# Altium schematic sheet Microbit built-in.SchDoc (continued)

## Library data of the parts
C38 C39 C46 = CAP CC0402JRNPO9BN240: Ceramic Capacitor, Multilayer, Ceramic, 50V, 5% +Tol, 5% -Tol, C0G, -/+30ppm/Cel TC, 0.000024uF, Surface Mount, 0402; Manufacturer: Yageo; Multilayer: Yes; Capacitor Type: Ceramic; Category: Ceramic Capacitors; Component Kind: Standard; ComponentLink1Description: https://datasheet.ciiva.com:443/pdfs/VipMasterIC/IC/YAGO/YAGOS02297/YAGOS02297-1.pdf?src-supplier=IHS+Markit; ComponentLink1URL: https://datasheet.ciiva.com:443/pdfs/VipMasterIC/IC/YAGO/YAGOS02297/YAGOS02297-1.pdf?src-supplier=IHS+Markit; ComponentLink2Description: https://datasheet.ciiva.com/144/mlccorderingcode-144079.pdf?src-supplier=Digikey; ComponentLink2URL: https://datasheet.ciiva.com/144/mlccorderingcode-144079.pdf?src-supplier=Digikey; ComponentLink3Description: https://datasheet.ciiva.com/144/mlccorderingcode-144080.pdf?src-supplier=Digikey; ComponentLink3URL: https://datasheet.ciiva.com/144/mlccorderingcode-144080.pdf?src-supplier=Digikey; ComponentLink4Description: https://datasheet.ciiva.com/144/mlccorderingcode-144081.pdf?src-supplier=Digikey; ComponentLink4URL: https://datasheet.ciiva.com/144/mlccorderingcode-144081.pdf?src-supplier=Digikey; ComponentLink5Description: https://datasheet.ciiva.com/13386/upy-gp-np0-16v-to-50v-8-13386235.pdf?src-supplier=Mouser; ComponentLink5URL: https://datasheet.ciiva.com/13386/upy-gp-np0-16v-to-50v-8-13386235.pdf?src-supplier=Mouser; ComponentLink6Description: https://datasheet.ciiva.com/7656/1132289-7656617.pdf?src-supplier=Element14; ComponentLink6URL: https://datasheet.ciiva.com/7656/1132289-7656617.pdf?src-supplier=Element14; Dielectric Material: Ceramic; JESD-609 Code: e3; Manufacturer Part Number: CC0402JRNPO9BN240; Max Operating Temperature: 125°C; Min Operating Temperature: -55°C; Mount: Surface Mount; Negative Tolerance: 5%; Number of Pins: 2; Package Shape: Rectangular; Packaging: Tape and Reel; Positive Tolerance: 5%; Pricing 1: 1=0.1, 10=0.027, 50=0.0156, 100=0.0122, 500=0.00916, 1000=0.00719, 2500=0.00654, 5000=0.00602 (USD); Rated DC Voltage (URdc): 50V; Reach SVHC Compliant: Yes; RoHS: Yes; RoHS Compliant: Yes; Size Code: 0402; Stock 1: 195258; Supplier 1: Digikey; Supplier Part Number 1: 311-1348-6-ND; Temperature Characteristics Code: C0G; Terminal Finish: Nickel; Termination: Wraparound
  C38 C39: Library Name: bBoard for UNB Rev 4.SCHLIB
  C46: Library Name: bBoard for UNB Rev 3.SCHLIB
C40 C42 = CAP CL05C101JB5NNNC: Ceramic Capacitor, Multilayer, Ceramic, 50V, 5% +Tol, 5% -Tol, C0G, -/+30ppm/Cel TC, 0.0001uF, Surface Mount, 0402; Manufacturer: Samsung; Multilayer: Yes; Capacitor Type: Ceramic; Category: Ceramic Capacitors; Component Kind: Standard; ComponentLink1Description: https://datasheet.ciiva.com:443/pdfs/VipMasterIC/IC/SEMS/SEMS-S-A0008529205/SEMS-S-A0008529205-1.pdf?src-supplier=IHS+Markit; ComponentLink1URL: https://datasheet.ciiva.com:443/pdfs/VipMasterIC/IC/SEMS/SEMS-S-A0008529205/SEMS-S-A0008529205-1.pdf?src-supplier=IHS+Markit; ComponentLink2Description: https://datasheet.ciiva.com/15195/getdatasheetpartid-357528-15195665.pdf?src-supplier=Verical; ComponentLink2URL: https://datasheet.ciiva.com/15195/getdatasheetpartid-357528-15195665.pdf?src-supplier=Verical; ComponentLink3Description: https://datasheet.ciiva.com/29198/458492-da-01-en-vs-kondensator-0402-29198529.pdf?src-supplier=Conrad; ComponentLink3URL: https://datasheet.ciiva.com/29198/458492-da-01-en-vs-kondensator-0402-29198529.pdf?src-supplier=Conrad; ComponentLink4Description: https://datasheet.ciiva.com/16379/cl05b104kq5nnnc-16379226.pdf?src-supplier=Future+Electronics; ComponentLink4URL: https://datasheet.ciiva.com/16379/cl05b104kq5nnnc-16379226.pdf?src-supplier=Future+Electronics; Dielectric Material: Ceramic; Height: 0.55mm; JESD-609 Code: e3; Length: 1mm; Manufacturer Part Number: CL05C101JB5NNNC; Max Operating Temperature: 125°C; Min Operating Temperature: -55°C; Mount: Surface Mount; Negative Tolerance: 5%; Number of Pins: 2; Package Shape: Rectangular; Package Style: SMTMeter; Packaging: Tape and Reel; Positive Tolerance: 5%; Pricing 1: 1=0.1, 10=0.017, 50=0.01, 100=0.0077, 500=0.00584, 1000=0.00458, 2500=0.00417, 5000=0.00383 (USD); Rated DC Voltage (URdc): 50V; Reach SVHC Compliant: Yes; RoHS: Yes; RoHS Compliant: Yes; Size Code: 0402; Stock 1: 4909001; Supplier 1: Digikey; Supplier Part Number 1: 1276-1025-6-ND; Temperature Characteristics Code: C0G; Terminal Finish: Nickel; Termination: Wraparound; Width: 0.5mm; Library Name: bBoard for UNB Rev 3.SCHLIB
C41 = CAP GRM155R71E103KA01D: Ceramic Capacitor, Multilayer, Ceramic, 25V, 10% +Tol, 10% -Tol, X7R, 15% TC, 0.01uF, Surface Mount, 0402; Manufacturer: Murata; Multilayer: Yes; Capacitor Type: Ceramic; Category: Ceramic Capacitors; Component Kind: Standard; ComponentLink1Description: https://psearch.en.murata.com:443/capacitor/product/GRM155R71E103KA01%23.pdf?src-supplier=IHS+Markit; ComponentLink1URL: https://psearch.en.murata.com:443/capacitor/product/GRM155R71E103KA01%23.pdf?src-supplier=IHS+Markit; ComponentLink2Description: https://datasheet.ciiva.com/28534/1699889-28534148.pdf?src-supplier=Element14; ComponentLink2URL: https://datasheet.ciiva.com/28534/1699889-28534148.pdf?src-supplier=Element14; ComponentLink3Description: https://datasheet.ciiva.com/11786/0900766b807a1cab-11786320.pdf?src-supplier=Rs; ComponentLink3URL: https://datasheet.ciiva.com/11786/0900766b807a1cab-11786320.pdf?src-supplier=Rs; ComponentLink4Description: https://datasheet.ciiva.com/129/partnumbering-e-01-129741.pdf?src-supplier=Digikey; ComponentLink4URL: https://datasheet.ciiva.com/129/partnumbering-e-01-129741.pdf?src-supplier=Digikey; ComponentLink5Description: https://datasheet.ciiva.com/129/partnumbering-e-01-129742.pdf?src-supplier=Digikey; ComponentLink5URL: https://datasheet.ciiva.com/129/partnumbering-e-01-129742.pdf?src-supplier=Digikey; ComponentLink6Description: https://datasheet.ciiva.com/129/partnumbering-e-01-129743.pdf?src-supplier=Digikey; ComponentLink6URL: https://datasheet.ciiva.com/129/partnumbering-e-01-129743.pdf?src-supplier=Digikey; ComponentLink7Description: https://datasheet.ciiva.com/3777/3813grm155r71e103ka0123-3777115.pdf?src-supplier=Arrow+Electronics; ComponentLink7URL: https://datasheet.ciiva.com/3777/3813grm155r71e103ka0123-3777115.pdf?src-supplier=Arrow+Electronics; ComponentLink8Description: https://datasheet.ciiva.com/18184/getdatasheetpartid-161489-18184689.pdf?src-supplier=Verical; ComponentLink8URL: https://datasheet.ciiva.com/18184/getdatasheetpartid-161489-18184689.pdf?src-supplier=Verical; ComponentLink9Description: https://datasheet.ciiva.com/29199/454154-da-01-en-vs-kondensator-0402-29199817.pdf?src-supplier=Conrad; ComponentLink9URL: https://datasheet.ciiva.com/29199/454154-da-01-en-vs-kondensator-0402-29199817.pdf?src-supplier=Conrad; ComponentLink10Description: https://datasheet.ciiva.com/7937/1747448-7937621.pdf?src-supplier=Element14; ComponentLink10URL: https://datasheet.ciiva.com/7937/1747448-7937621.pdf?src-supplier=Element14; ComponentLink11Description: https://datasheet.ciiva.com/16199/product-16199034.pdf?src-supplier=Future+Electronics; ComponentLink11URL: https://datasheet.ciiva.com/16199/product-16199034.pdf?src-supplier=Future+Electronics; Dielectric Material: Ceramic; Height: 0.5mm; JESD-609 Code: e3; Length: 1mm; Manufacturer Part Number: GRM155R71E103KA01D; Max Operating Temperature: 125°C; Min Operating Temperature: -55°C; Mount: Surface Mount; Negative Tolerance: 10%; Number of Pins: 2; Package Shape: Rectangular; Package Style: SMTMeter; Packaging: Tape and Reel; Positive Tolerance: 10%; Pricing 1: 1=0.1, 10=0.028, 50=0.016, 100=0.0125, 500=0.00938, 1000=0.00736, 2500=0.0067, 5000=0.00616 (USD); Rated DC Voltage (URdc): 25V; Reach SVHC Compliant: Yes; RoHS: Yes; RoHS Compliant: Yes; Size Code: 0402; Stock 1: 9724728; Supplier 1: Digikey; Supplier Part Number 1: 490-1312-1-ND; Temperature Characteristics Code: X7R; Terminal Finish: Nickel; Termination: Wraparound; Width: 0.5mm; Library Name: bBoard for UNB Rev 3.SCHLIB
C43 C45 C49-C51 = CAP GRM155R61H104KE14D: Ceramic Capacitor, Multilayer, Ceramic, 50V, 10% +Tol, 10% -Tol, X5R, 15% TC, 0.1uF, Surface Mount, 0402; Manufacturer: Murata; Multilayer: Yes; Capacitor Type: Ceramic; Category: Ceramic Capacitors; Component Kind: Standard; ComponentLink1Description: https://datasheet.ciiva.com:443/pdfs/VipMasterIC/IC/MURE/MURE-S-A0004362469/MURE-S-A0004364758-1.pdf?src-supplier=IHS+Markit; ComponentLink1URL: https://datasheet.ciiva.com:443/pdfs/VipMasterIC/IC/MURE/MURE-S-A0004362469/MURE-S-A0004364758-1.pdf?src-supplier=IHS+Markit; ComponentLink2Description: http://www.mouser.com/ds/2/281/c02e-2905.pdf?src-supplier=Mouser; ComponentLink2URL: http://www.mouser.com/ds/2/281/c02e-2905.pdf?src-supplier=Mouser; ComponentLink3Description: http://www.farnell.com/datasheets/2079150.pdf?src-supplier=Farnell; ComponentLink3URL: http://www.farnell.com/datasheets/2079150.pdf?src-supplier=Farnell; ComponentLink4Description: http://www.farnell.com/datasheets/2079150.pdf?src-supplier=Element14; ComponentLink4URL: http://www.farnell.com/datasheets/2079150.pdf?src-supplier=Element14; ComponentLink5Description: https://datasheet.octopart.com/GRM155R61H104KE14D-Murata-datasheet-66077066.pdf?src-supplier=Element14; ComponentLink5URL: https://datasheet.octopart.com/GRM155R61H104KE14D-Murata-datasheet-66077066.pdf?src-supplier=Element14; ComponentLink6Description: http://search.murata.co.jp/Ceramy/image/img/A01X/G101/ENG/GRM155R61H104KE14-01.pdf?src-supplier=Digikey; ComponentLink6URL: http://search.murata.co.jp/Ceramy/image/img/A01X/G101/ENG/GRM155R61H104KE14-01.pdf?src-supplier=Digikey; ComponentLink7Description: https://datasheet.octopart.com/GRM155R61H104KE14D-Murata-datasheet-22122223.pdf?src-supplier=Future+Electronics; ComponentLink7URL: https://datasheet.octopart.com/GRM155R61H104KE14D-Murata-datasheet-22122223.pdf?src-supplier=Future+Electronics; ComponentLink8Description: https://datasheet.octopart.com/GRM155R61H104KE14D-Murata-datasheet-149523029.pdf?src-supplier=Newark; ComponentLink8URL: https://datasheet.octopart.com/GRM155R61H104KE14D-Murata-datasheet-149523029.pdf?src-supplier=Newark; Dielectric Material: Ceramic; Height: 0.5mm; JESD-609 Code: e3; Length: 1mm; Manufacturer Part Number: GRM155R61H104KE14D; Max Operating Temperature: 85°C; Min Operating Temperature: -55°C; Mount: Surface Mount; Negative Tolerance: 10%; Number of Pins: 2; Package Shape: Rectangular; Package Style: SMTMeter; Packaging: Tape and Reel; Positive Tolerance: 10%; Pricing 1: 1=0.1, 10=0.031, 50=0.018, 100=0.0139, 500=0.01046, 1000=0.00821, 2500=0.00747, 5000=0.00687 (USD); Rated DC Voltage (URdc): 50V; Reach SVHC Compliant: Yes; RoHS: Yes; RoHS Compliant: Yes; Size Code: 0402; Stock 1: 1615754; Supplier 1: Digikey; Temperature Characteristics Code: X5R; Terminal Finish: Nickel; Termination: Wraparound; Width: 0.5mm; Library Name: bBoard for UNB Rev 3.SCHLIB
C44 C47 = CAP CC0402KRX5R5BB105: Ceramic Capacitor, Multilayer, Ceramic, 6.3V, 10% +Tol, 10% -Tol, X5R, 15% TC, 1uF, Surface Mount, 0402; Manufacturer: Yageo; Multilayer: Yes; Capacitor Type: Ceramic; Category: Ceramic Capacitors; Component Kind: Standard; ComponentLink1Description: https://datasheet.ciiva.com:443/pdfs/VipMasterIC/IC/YAGO/YAGO-S-A0006851646/YAGO-S-A0006851646-1.pdf?src-supplier=IHS+Markit; ComponentLink1URL: https://datasheet.ciiva.com:443/pdfs/VipMasterIC/IC/YAGO/YAGO-S-A0006851646/YAGO-S-A0006851646-1.pdf?src-supplier=IHS+Markit; ComponentLink2Description: https://datasheet.ciiva.com/5789/70765-5789520.pdf?src-supplier=Farnell; ComponentLink2URL: https://datasheet.ciiva.com/5789/70765-5789520.pdf?src-supplier=Farnell; ComponentLink3Description: https://datasheet.ciiva.com/176/mlccorderingcode-176609.pdf?src-supplier=Digikey; ComponentLink3URL: https://datasheet.ciiva.com/176/mlccorderingcode-176609.pdf?src-supplier=Digikey; ComponentLink4Description: https://datasheet.ciiva.com/176/mlccorderingcode-176610.pdf?src-supplier=Digikey; ComponentLink4URL: https://datasheet.ciiva.com/176/mlccorderingcode-176610.pdf?src-supplier=Digikey; ComponentLink5Description: https://datasheet.ciiva.com/176/mlccorderingcode-176611.pdf?src-supplier=Digikey; ComponentLink5URL: https://datasheet.ciiva.com/176/mlccorderingcode-176611.pdf?src-supplier=Digikey; ComponentLink6Description: https://datasheet.ciiva.com/11464/upy-gphc-x5r-4v-to-50v-12-11464863.pdf?src-supplier=Avnet; ComponentLink6URL: https://datasheet.ciiva.com/11464/upy-gphc-x5r-4v-to-50v-12-11464863.pdf?src-supplier=Avnet; ComponentLink7Description: https://datasheet.ciiva.com/8033/1525783-8033643.pdf?src-supplier=Element14; ComponentLink7URL: https://datasheet.ciiva.com/8033/1525783-8033643.pdf?src-supplier=Element14; ComponentLink8Description: https://datasheet.ciiva.com/8033/1525783-8033644.pdf?src-supplier=Element14; ComponentLink8URL: https://datasheet.ciiva.com/8033/1525783-8033644.pdf?src-supplier=Element14; ComponentLink9Description: https://datasheet.ciiva.com/8033/70765-8033646.pdf?src-supplier=Element14; ComponentLink9URL: https://datasheet.ciiva.com/8033/70765-8033646.pdf?src-supplier=Element14; ComponentLink10Description: https://datasheet.ciiva.com/28450/1359354-28450285.pdf?src-supplier=Element14; ComponentLink10URL: https://datasheet.ciiva.com/28450/1359354-28450285.pdf?src-supplier=Element14; Dielectric Material: Ceramic; Height: 0.5mm; JESD-609 Code: e3; Length: 1mm; Manufacturer Part Number: CC0402KRX5R5BB105; Max Operating Temperature: 85°C; Min Operating Temperature: -55°C; Mount: Surface Mount; Negative Tolerance: 10%; Number of Pins: 2; Package Shape: Rectangular; Package Style: SMTMeter; Packaging: Tape and Reel; Positive Tolerance: 10%; Pricing 1: 1=0.1, 10=0.054, 50=0.0308, 100=0.0239, 500=0.01796, 1000=0.01412, 2500=0.01283, 5000=0.01181 (USD); Rated DC Voltage (URdc): 6.3V; Reach SVHC Compliant: Yes; RoHS: Yes; RoHS Compliant: Yes; Size Code: 0402; Stock 1: 21426522; Supplier 1: Digikey; Supplier Part Number 1: 311-1438-1-ND; Temperature Characteristics Code: X5R; Terminal Finish: Nickel; Termination: Wraparound; Width: 0.5mm; Library Name: bBoard for UNB Rev 3.SCHLIB
C48 = CAP GRM188R60J106ME47D: Ceramic Capacitor, Multilayer, Ceramic, 6.3V, 20% +Tol, 20% -Tol, X5R, 15% TC, 10uF, Surface Mount, 0603; Manufacturer: Murata; Multilayer: Yes; Capacitor Type: Ceramic; Category: Ceramic Capacitors; Component Kind: Standard; ComponentLink1Description: https://datasheet.ciiva.com:443/pdfs/VipMasterIC/IC/MURE/MURE-S-A0008911298/MURE-S-A0008911290-1.pdf?src-supplier=IHS+Markit; ComponentLink1URL: https://datasheet.ciiva.com:443/pdfs/VipMasterIC/IC/MURE/MURE-S-A0008911298/MURE-S-A0008911290-1.pdf?src-supplier=IHS+Markit; ComponentLink2Description: https://datasheet.ciiva.com/22776/1747457-22776418.pdf?src-supplier=Farnell; ComponentLink2URL: https://datasheet.ciiva.com/22776/1747457-22776418.pdf?src-supplier=Farnell; ComponentLink3Description: https://datasheet.ciiva.com/28584/415295-28584232.pdf?src-supplier=Element14; ComponentLink3URL: https://datasheet.ciiva.com/28584/415295-28584232.pdf?src-supplier=Element14; ComponentLink4Description: https://datasheet.ciiva.com/3777/10175grm188r60j106me4723-3777268.pdf?src-supplier=Arrow+Electronics; ComponentLink4URL: https://datasheet.ciiva.com/3777/10175grm188r60j106me4723-3777268.pdf?src-supplier=Arrow+Electronics; ComponentLink5Description: https://datasheet.ciiva.com/29199/454401-da-01-en-vs-kondensator-0603-29199677.pdf?src-supplier=Conrad; ComponentLink5URL: https://datasheet.ciiva.com/29199/454401-da-01-en-vs-kondensator-0603-29199677.pdf?src-supplier=Conrad; ComponentLink6Description: https://datasheet.ciiva.com/29202/450781-da-01-de-vs-chip-kond-0603-10-0-uf-20pr-6-3v-x5r-29202806.pdf?src-supplier=Conrad; ComponentLink6URL: https://datasheet.ciiva.com/29202/450781-da-01-de-vs-chip-kond-0603-10-0-uf-20pr-6-3v-x5r-29202806.pdf?src-supplier=Conrad; ComponentLink7Description: https://datasheet.ciiva.com/138/partnumbering-e-01-138658.pdf?src-supplier=Digikey; ComponentLink7URL: https://datasheet.ciiva.com/138/partnumbering-e-01-138658.pdf?src-supplier=Digikey; ComponentLink8Description: https://datasheet.ciiva.com/138/partnumbering-e-01-138659.pdf?src-supplier=Digikey; ComponentLink8URL: https://datasheet.ciiva.com/138/partnumbering-e-01-138659.pdf?src-supplier=Digikey; ComponentLink9Description: https://datasheet.ciiva.com/138/partnumbering-e-01-138660.pdf?src-supplier=Digikey; ComponentLink9URL: https://datasheet.ciiva.com/138/partnumbering-e-01-138660.pdf?src-supplier=Digikey; ComponentLink10Description: https://datasheet.ciiva.com/14116/grmseries-highdielectrictype-eng-14116428.pdf?src-supplier=Distrelec; ComponentLink10URL: https://datasheet.ciiva.com/14116/grmseries-highdielectrictype-eng-14116428.pdf?src-supplier=Distrelec; ComponentLink11Description: https://datasheet.ciiva.com/16373/l0005e-16373580.pdf?src-supplier=Future+Electronics; ComponentLink11URL: https://datasheet.ciiva.com/16373/l0005e-16373580.pdf?src-supplier=Future+Electronics; Dielectric Material: Ceramic; Height: 0.8mm; JESD-609 Code: e3; Length: 1.6mm; Manufacturer Part Number: GRM188R60J106ME47D; Max Operating Temperature: 85°C; Min Operating Temperature: -55°C; Mount: Surface Mount; Negative Tolerance: 20%; Number of Pins: 2; Package Shape: Rectangular; Package Style: SMTMeter; Packaging: Tape and Reel; Positive Tolerance: 20%; Pricing 1: 1=0.17, 10=0.116, 50=0.0692, 100=0.0544, 500=0.04022, 1000=0.03329 (USD); Rated DC Voltage (URdc): 6.3V; Reach SVHC Compliant: Yes; RoHS: Yes; RoHS Compliant: Yes; Size Code: 0603; Stock 1: 1071844; Supplier 1: Digikey; Supplier Part Number 1: 490-3896-1-ND; Temperature Characteristics Code: X5R; Terminal Finish: Nickel; Termination: Wraparound; Width: 0.8mm; Library Name: bBoard for UNB Rev 3.SCHLIB
C53 = CAP GJM1555C1H1R2JB01D: Ceramic Capacitor, Multilayer, Ceramic, 50V, 5% +Tol, 5% -Tol, C0G, 30ppm/Cel TC, 0.0000012uF, Surface Mount, 0402; Manufacturer: Murata; Multilayer: Yes; Capacitor Type: Ceramic; Category: Ceramic Capacitors; Component Kind: Standard; ComponentLink1Description: https://datasheet.ciiva.com:443/pdfs/VipMasterIC/IC/MURE/MURE-S-A0004378008/MURE-S-A0004383049-1.pdf?src-supplier=IHS+Markit; ComponentLink1URL: https://datasheet.ciiva.com:443/pdfs/VipMasterIC/IC/MURE/MURE-S-A0004378008/MURE-S-A0004383049-1.pdf?src-supplier=IHS+Markit; ComponentLink2Description: http://datasheet.octopart.com/GJM1555C1H1R2JB01D-Murata-datasheet-8819587.pdf?src-supplier=Farnell; ComponentLink2URL: http://datasheet.octopart.com/GJM1555C1H1R2JB01D-Murata-datasheet-8819587.pdf?src-supplier=Farnell; ComponentLink3Description: http://datasheet.octopart.com/GJM1555C1H1R2JB01D-Murata-datasheet-8819587.pdf?src-supplier=Digikey; ComponentLink3URL: http://datasheet.octopart.com/GJM1555C1H1R2JB01D-Murata-datasheet-8819587.pdf?src-supplier=Digikey; ComponentLink4Description: http://datasheet.octopart.com/GJM1555C1H1R2JB01D-Murata-datasheet-8819587.pdf?src-supplier=Avnet; ComponentLink4URL: http://datasheet.octopart.com/GJM1555C1H1R2JB01D-Murata-datasheet-8819587.pdf?src-supplier=Avnet; ComponentLink5Description: http://datasheet.octopart.com/GJM1555C1H1R2JB01D-Murata-datasheet-8819587.pdf?src-supplier=Mouser; ComponentLink5URL: http://datasheet.octopart.com/GJM1555C1H1R2JB01D-Murata-datasheet-8819587.pdf?src-supplier=Mouser; Dielectric Material: Ceramic; Manufacturer Part Number: GJM1555C1H1R2JB01D; Max Operating Temperature: 125°C; Min Operating Temperature: -55°C; Mount: Surface Mount; Negative Tolerance: 5%; Number of Pins: 2; Package Shape: Rectangular; Packaging: Tape and Reel; Positive Tolerance: 5%; Pricing 1: 1=0.12, 10=0.081, 50=0.0484, 100=0.0381, 500=0.02816, 1000=0.02331, 2500=0.02169, 5000=0.01984 (USD); Rated DC Voltage (URdc): 50V; Reach SVHC Compliant: Yes; RoHS: Yes; RoHS Compliant: Yes; Size Code: 0402; Stock 1: 11165; Supplier 1: Digikey; Supplier Part Number 1: 490-GJM1555C1H1R2JB01DCT-ND; Temperature Characteristics Code: C0G; Termination: Wraparound; Library Name: bBoard for UNB Rev 4.SCHLIB
C54 = CAP GCM1555C1H1R0JA16D: Ceramic Capacitor, Multilayer, Ceramic, 50V, 5% +Tol, 5% -Tol, C0G, 30ppm/Cel TC, 0.000001uF, Surface Mount, 0402; Manufacturer: Murata; Multilayer: Yes; Reference Standard: AEC-Q200; Capacitor Type: Ceramic; Category: Ceramic Capacitors; Component Kind: Standard; ComponentLink1Description: https://psearch.en.murata.com:443/capacitor/product/GCM1555C1H1R0JA16%23.pdf?src-supplier=IHS+Markit; ComponentLink1URL: https://psearch.en.murata.com:443/capacitor/product/GCM1555C1H1R0JA16%23.pdf?src-supplier=IHS+Markit; ComponentLink2Description: https://datasheet.octopart.com/GCM1555C1H1R0JA16D-Murata-datasheet-12511495.pdf?src-supplier=TME; ComponentLink2URL: https://datasheet.octopart.com/GCM1555C1H1R0JA16D-Murata-datasheet-12511495.pdf?src-supplier=TME; Dielectric Material: Ceramic; Manufacturer Part Number: GCM1555C1H1R0JA16D; Max Operating Temperature: 125°C; Min Operating Temperature: -55°C; Mount: Surface Mount; Negative Tolerance: 5%; Number of Pins: 2; Package Shape: Rectangular; Packaging: Tape and Reel; Positive Tolerance: 5%; Pricing 1: 1=0.1, 10=0.046, 50=0.0266, 100=0.0206, 500=0.0155, 1000=0.01218, 2500=0.01107, 5000=0.01019 (USD); Rated DC Voltage (URdc): 50V; Reach SVHC Compliant: Yes; RoHS: Yes; RoHS Compliant: Yes; Size Code: 0402; Stock 1: 43826; Supplier 1: Digikey; Supplier Part Number 1: 490-GCM1555C1H1R0JA16DCT-ND; Temperature Characteristics Code: C0G; Termination: Wraparound; Library Name: bBoard for UNB Rev 4.SCHLIB
D4 = LED_0603_RED: LED, Red, 630 nm, 1.9 V, 30 mA, 60 mcd; Manufacturer: Wurth Electronics; Manufacturer Part Number: 150060SS75000; Dominant Wavelength: 630nm; Forward Current: 30mA; Forward Voltage: 1.9V; Lens Style: Clear,Rectangular; Luminous Intensity: 60mcd; Test Current: 20mA; Viewing Angle: 140°; Case Code (Imperial): 0603; Case Code (Metric): 1608; Case/Package: 0603; Category: LEDs; Color: Red; Component Kind: Standard; ComponentLink1Description: https://datasheet.ciiva.com/23843/150060ss75000-23843248.pdf?src-supplier=Newark; ComponentLink1URL: https://datasheet.ciiva.com/23843/150060ss75000-23843248.pdf?src-supplier=Newark; ComponentLink2Description: https://datasheet.ciiva.com/29279/759429-da-01-en-chipled-0603-w-kl-s-rot-150060ss75000-29279208.pdf?src-supplier=Conrad; ComponentLink2URL: https://datasheet.ciiva.com/29279/759429-da-01-en-chipled-0603-w-kl-s-rot-150060ss75000-29279208.pdf?src-supplier=Conrad; ComponentLink3Description: https://datasheet.ciiva.com/48430/150060ss75000-eng-tds-48430042.pdf?src-supplier=Distrelec; ComponentLink3URL: https://datasheet.ciiva.com/48430/150060ss75000-eng-tds-48430042.pdf?src-supplier=Distrelec; Height: 0.7mm; Length: 1.6mm; Max Operating Temperature: 85°C; Min Operating Temperature: -40°C; Mount: Surface Mount; Package Quantity: 4000; Packaging: Tape and Reel; REACH SVHC: Unknown; RoHS: Yes; Stock 1: 15937; Supplier 1: Digi-Key; Supplier Part Number 1: 732-4979-1-ND; Wavelength: 630nm; Width: 0.8mm; Library Name: bBoard for UNB Rev 4.SCHLIB
D5 = DIODE BAS16LD,315: Rectifier Diode, 1 Phase, 1 Element, 0.215A, 100V V(RRM), Silicon; Manufacturer: Nexperia; Max Output Current: 215mA; Max Power Dissipation: 250mW; Number of Elements: 1; Package Body Material: Plastic; Reference Standard: AEC-Q101; Reverse Recovery Time-Max: 0.004us; Time @ Peak Reflow Temperature-Max (s): 30s; Category: Rectifier Diodes; Component Kind: Standard; ComponentLink1Description: https://datasheet.ciiva.com:443/pdfs/VipMasterIC/IC/NEXP/NEXP-S-A0002883683/NEXP-S-A0002883683-1.pdf?src-supplier=IHS+Markit; ComponentLink1URL: https://datasheet.ciiva.com:443/pdfs/VipMasterIC/IC/NEXP/NEXP-S-A0002883683/NEXP-S-A0002883683-1.pdf?src-supplier=IHS+Markit; Diode Element Material: SILICON; Diode Type: RECTIFIER; JESD-609 Code: e3; Manufacturer Part Number: BAS16LD,315; Max Operating Temperature: 150°C; Min Operating Temperature: -55°C; Moisture Sensitivity Level: 1; Number of Pins: 2; Package Shape: Rectangular; Package Style: SMALL OUTLINEMeter; Peak Reflow Temperature (Cel): 260°C; Pricing 1: 1=0.26, 10=0.176, 100=0.0859, 500=0.07168, 1000=0.04981, 2000=0.04317, 5000=0.04004 (USD); Reach SVHC Compliant: Yes; Rep Pk Reverse Voltage-Max: 100V; RoHS: Yes; RoHS Compliant: Yes; Stock 1: 205336; Supplier 1: Digikey; Supplier Part Number 1: 1727-4421-1-ND; Surface Mount: Yes; Terminal Finish: Tin; Terminal Form: No Lead; Terminal Position: DUAL; Library Name: bBoard for UNB Rev 4.SCHLIB
E1 = Antenna: RF ANT 2.4GHZ CHIP SOLDER SMD; Manufacturer: Linx; Bandwidth: 180MHz; Frequency: 2.45GHz; Gain: 0.5dB; Impedance: 50R; Max Power Dissipation: 3W; Number of Bands: 1; Case/Package: SMD/SMT; Category: RF Antennas; Component Kind: Standard; ComponentLink1Description: https://datasheet.ciiva.com/16287/ant-xxx-chp-x-16287420.pdf?src-supplier=Future+Electronics; ComponentLink1URL: https://datasheet.ciiva.com/16287/ant-xxx-chp-x-16287420.pdf?src-supplier=Future+Electronics; ComponentLink2Description: https://datasheet.ciiva.com/2792/ant-xxx-chp-x-2792221.pdf?src-supplier=Digi-Key; ComponentLink2URL: https://datasheet.ciiva.com/2792/ant-xxx-chp-x-2792221.pdf?src-supplier=Digi-Key; ComponentLink3Description: https://datasheet.ciiva.com/2792/ant-xxx-chp-x-2792222.pdf?src-supplier=Digi-Key; ComponentLink3URL: https://datasheet.ciiva.com/2792/ant-xxx-chp-x-2792222.pdf?src-supplier=Digi-Key; ComponentLink4Description: https://datasheet.ciiva.com/2792/ant-xxx-chp-x-2792223.pdf?src-supplier=Digi-Key; ComponentLink4URL: https://datasheet.ciiva.com/2792/ant-xxx-chp-x-2792223.pdf?src-supplier=Digi-Key; ComponentLink5Description: https://datasheet.ciiva.com/4432/ant-xxx-chp-x-data-guide-11938-4432415.pdf?src-supplier=Mouser; ComponentLink5URL: https://datasheet.ciiva.com/4432/ant-xxx-chp-x-data-guide-11938-4432415.pdf?src-supplier=Mouser; Height: 0.002inch; Lead Free: Lead Free; Length: 0.26inch; Manufacturer Part Number: ANT-2.45-CHP-T; Max Operating Temperature: 85°C; Min Operating Temperature: -40°C; Mount: Surface Mount; Package Quantity: 1; Packaging: Tape and Reel; RoHS: Yes; Stock 1: 10182; Supplier 1: Digi-Key; Supplier Part Number 1: ANT-2.45-CHPCT-ND; Termination: Solder; Width: 0.09inch; Library Name: bBoard for UNB Rev 4.SCHLIB
L5 = LQM18FN100M00D: General Purpose Inductor, 10uH, 20%, 1 Element, Ferrite-Core, SMD, 0603; Manufacturer: Murata; Case/Size Code: 0603; DC Resistance (DCR): 1.17R; Inductor Application: RF INDUCTOR; Number of Functions: 1; Package Height: 0.8mm; Package Length: 1.6mm; Rated Current-Max: 50mA; Self Resonant Frequency: 30MHz; Shape/Size Description: RECTANGULAR PACKAGE; Shielding: Shielded; Test Frequency: 1MHz; Category: Fixed Inductors; Component Kind: Standard; ComponentLink1Description: https://psearch.en.murata.com:443/inductor/product/LQM18FN100M00_.pdf?src-supplier=IHS+Markit; ComponentLink1URL: https://psearch.en.murata.com:443/inductor/product/LQM18FN100M00_.pdf?src-supplier=IHS+Markit; ComponentLink2Description: https://datasheet.ciiva.com/3865/286lqm18fn100m0023-3865365.pdf?src-supplier=Arrow+Electronics; ComponentLink2URL: https://datasheet.ciiva.com/3865/286lqm18fn100m0023-3865365.pdf?src-supplier=Arrow+Electronics; ComponentLink3Description: https://datasheet.ciiva.com/11799/0900766b80f15512-11799471.pdf?src-supplier=Rs; ComponentLink3URL: https://datasheet.ciiva.com/11799/0900766b80f15512-11799471.pdf?src-supplier=Rs; ComponentLink4Description: https://datasheet.ciiva.com/1039/l0075s0097lqm18f-1039417.pdf?src-supplier=Digikey; ComponentLink4URL: https://datasheet.ciiva.com/1039/l0075s0097lqm18f-1039417.pdf?src-supplier=Digikey; ComponentLink5Description: https://datasheet.ciiva.com/1039/l0075s0097lqm18f-1039418.pdf?src-supplier=Digikey; ComponentLink5URL: https://datasheet.ciiva.com/1039/l0075s0097lqm18f-1039418.pdf?src-supplier=Digikey; ComponentLink6Description: https://datasheet.ciiva.com/1039/l0075s0097lqm18f-1039419.pdf?src-supplier=Digikey; ComponentLink6URL: https://datasheet.ciiva.com/1039/l0075s0097lqm18f-1039419.pdf?src-supplier=Digikey; ComponentLink7Description: https://datasheet.ciiva.com/8510/l0075s0097lqm18f-8510087.pdf?src-supplier=Avnet; ComponentLink7URL: https://datasheet.ciiva.com/8510/l0075s0097lqm18f-8510087.pdf?src-supplier=Avnet; ComponentLink8Description: https://datasheet.ciiva.com/8510/l0075s0097lqm18f-8510328.pdf?src-supplier=Avnet; ComponentLink8URL: https://datasheet.ciiva.com/8510/l0075s0097lqm18f-8510328.pdf?src-supplier=Avnet; Construction: Multilayer Chip; Core Material: Ferrite; Inductance-Nom (L): 10uH; Inductor Type: GENERAL PURPOSE INDUCTOR; JESD-609 Code: e3; Manufacturer Part Number: LQM18FN100M00D; Max Operating Temperature: 125°C; Min Operating Temperature: -55°C; Number of Pins: 2; Package Style: SMTMeter; Package Width: 0.8mm; Packaging: Tape and Reel; Pricing 1: 1=0.18, 10=0.149, 25=0.1372, 50=0.1212, 100=0.106, 250=0.10096, 500=0.09488, 1000=0.07268 (USD); Reach SVHC Compliant: Yes; RoHS: Yes; RoHS Compliant: Yes; Stock 1: 351983; Supplier 1: Digikey; Supplier Part Number 1: 490-4025-1-ND; Surface Mount: Yes; Terminal Finish: Tin; Terminal Placement: Dual Ended; Termination: Wraparound; Tolerance: 20%; Library Name: bBoard for UNB Rev 3.SCHLIB
L6 = MLT-8530: Speaker magnetic 9mm SMT; Manufacturer: Jiangsu Huaneng Elec; Component Kind: Standard; Manufacturer Part Number: MLT-8530; Supplier: LCSC; Library Name: bBoard for UNB Rev 4.SCHLIB
L7 = L AISC-0402-2N2J-T: General Purpose Inductor, 0.0022uH, 5%, 1 Element, Ceramic-Core, SMD, 0503; Manufacturer: Abracon; Case/Size Code: 0402; DC Resistance (DCR): 70mR; Inductor Application: RF INDUCTOR; Number of Functions: 1; Quality Factor-Min (at L-nom): 18; Rated Current-Max: 960mA; Self Resonant Frequency: 10.8GHz; Shape/Size Description: RECTANGULAR PACKAGE; Shielding: Unshielded; Test Frequency: 250MHz; Category: Fixed Inductors; Component Kind: Standard; ComponentLink1Description: https://datasheet.ciiva.com:443/pdfs/VipMasterIC/IC/ABRC/ABRC-S-A0009700385/ABRC-S-A0009751294-1.pdf?src-supplier=IHS+Markit; ComponentLink1URL: https://datasheet.ciiva.com:443/pdfs/VipMasterIC/IC/ABRC/ABRC-S-A0009700385/ABRC-S-A0009751294-1.pdf?src-supplier=IHS+Markit; ComponentLink2Description: https://datasheet.ciiva.com/1054/aisc-0402-1054033.pdf?src-supplier=Digikey; ComponentLink2URL: https://datasheet.ciiva.com/1054/aisc-0402-1054033.pdf?src-supplier=Digikey; ComponentLink3Description: https://datasheet.ciiva.com/1054/aisc-0402-1054034.pdf?src-supplier=Digikey; ComponentLink3URL: https://datasheet.ciiva.com/1054/aisc-0402-1054034.pdf?src-supplier=Digikey; ComponentLink4Description: https://datasheet.ciiva.com/1054/aisc-0402-1054035.pdf?src-supplier=Digikey; ComponentLink4URL: https://datasheet.ciiva.com/1054/aisc-0402-1054035.pdf?src-supplier=Digikey; ComponentLink5Description: https://datasheet.ciiva.com/11140/aisc-0402-11140263.pdf?src-supplier=Avnet; ComponentLink5URL: https://datasheet.ciiva.com/11140/aisc-0402-11140263.pdf?src-supplier=Avnet; Core Material: Ceramic; Inductor Type: GENERAL PURPOSE INDUCTOR; JESD-609 Code: e4; Manufacturer Part Number: AISC-0402-2N2J-T; Max Operating Temperature: 125°C; Min Operating Temperature: -40°C; Number of Pins: 2; Pricing 1: 1=0.27, 10=0.217, 25=0.2, 50=0.1834, 100=0.1617, 250=0.15664, 500=0.13998, 1000=0.11332, 2500=0.10666 (USD); Reach SVHC Compliant: Yes; RoHS: Yes; RoHS Compliant: Yes; Stock 1: 17497; Supplier 1: Digikey; Supplier Part Number 1: 535-10425-1-ND; Surface Mount: Yes; Terminal Finish: Nickel; Terminal Placement: Dual Ended; Termination: Wraparound; Tolerance: 5%; Library Name: bBoard for UNB Rev 4.SCHLIB
L8 = L CW100505-4N7J: General Purpose Inductor, 0.0047uH, 5%, 1 Element, Ceramic-Core, SMD, 0402; Manufacturer: Bourns; Case/Size Code: 0402; DC Resistance (DCR): 130mR; Inductor Application: RF INDUCTOR; Number of Functions: 1; Package Height: 0.5mm; Package Length: 1mm; Package Width: 0.55mm; Quality Factor-Min (at L-nom): 15; Rated Current-Max: 640mA; Self Resonant Frequency: 4.775GHz; Shape/Size Description: RECTANGULAR PACKAGE; Shielding: Unshielded; Test Frequency: 250MHz; Category: Fixed Inductors; Component Kind: Standard; ComponentLink1Description: https://datasheet.ciiva.com:443/pdfs/VipMasterIC/IC/BOUR/BOUR-S-A0006522652/BOUR-S-A0006522652-1.pdf?src-supplier=IHS+Markit; ComponentLink1URL: https://datasheet.ciiva.com:443/pdfs/VipMasterIC/IC/BOUR/BOUR-S-A0006522652/BOUR-S-A0006522652-1.pdf?src-supplier=IHS+Markit; ComponentLink2Description: https://datasheet.ciiva.com/6688/cw100505-6688922.pdf?src-supplier=Digikey; ComponentLink2URL: https://datasheet.ciiva.com/6688/cw100505-6688922.pdf?src-supplier=Digikey; ComponentLink3Description: https://datasheet.ciiva.com/6688/cw100505-6688926.pdf?src-supplier=Digikey; ComponentLink3URL: https://datasheet.ciiva.com/6688/cw100505-6688926.pdf?src-supplier=Digikey; ComponentLink4Description: https://datasheet.ciiva.com/3079/cw100505-3079092.pdf?src-supplier=Avnet; ComponentLink4URL: https://datasheet.ciiva.com/3079/cw100505-3079092.pdf?src-supplier=Avnet; ComponentLink5Description: https://datasheet.ciiva.com/3079/cw100505-3079781.pdf?src-supplier=Avnet; ComponentLink5URL: https://datasheet.ciiva.com/3079/cw100505-3079781.pdf?src-supplier=Avnet; ComponentLink6Description: https://datasheet.ciiva.com/17113/70250284-17113126.pdf?src-supplier=Allied+Electronics; ComponentLink6URL: https://datasheet.ciiva.com/17113/70250284-17113126.pdf?src-supplier=Allied+Electronics; ComponentLink7Description: https://datasheet.ciiva.com/7848/1758342-7848026.pdf?src-supplier=Element14; ComponentLink7URL: https://datasheet.ciiva.com/7848/1758342-7848026.pdf?src-supplier=Element14; Construction: Chip; Core Material: Ceramic; Inductor Type: GENERAL PURPOSE INDUCTOR; JESD-609 Code: e4; Manufacturer Part Number: CW100505-4N7J; Max Operating Temperature: 125°C; Min Operating Temperature: -55°C; Number of Pins: 2; Package Style: SMTMeter; Packaging: Tape and Reel; Pricing 1: 1=0.22, 10=0.179, 25=0.1648, 50=0.1512, 100=0.1333, 250=0.12916, 500=0.1154, 1000=0.09675 (USD); Reach SVHC Compliant: Yes; RoHS: Yes; RoHS Compliant: Yes; Stock 1: 280750; Supplier 1: Digikey; Supplier Part Number 1: CW100505-4N7JCT-ND; Surface Mount: Yes; Terminal Finish: Gold; Terminal Placement: Dual Ended; Termination: One Surface; Tolerance: 5%; Library Name: bBoard for UNB Rev 4.SCHLIB
P1 = Header 2X8 - 0901512116: Board Connector; Manufacturer: Molex; Component Kind: Standard; ComponentLink1Description: https://datasheet.ciiva.com:443/pdfs/VipMasterIC/IC/MOLE/MOLE-S-A0006864014/MOLE-S-A0006765232-1.pdf?src-supplier=IHS+Markit; ComponentLink1URL: https://datasheet.ciiva.com:443/pdfs/VipMasterIC/IC/MOLE/MOLE-S-A0006864014/MOLE-S-A0006765232-1.pdf?src-supplier=IHS+Markit; ComponentLink2Description: http://components.arrow.com/part/dataview/2928146S8734086N3303?src-supplier=Arrow+Electronics; ComponentLink2URL: http://components.arrow.com/part/dataview/2928146S8734086N3303?src-supplier=Arrow+Electronics; ComponentLink3Description: https://datasheet.octopart.com/90151-2116-Molex-datasheet-101584149.pdf?src-supplier=Farnell; ComponentLink3URL: https://datasheet.octopart.com/90151-2116-Molex-datasheet-101584149.pdf?src-supplier=Farnell; ComponentLink4Description: https://datasheet.octopart.com/90151-2116-Molex-datasheet-10854273.pdf?src-supplier=Newark; ComponentLink4URL: https://datasheet.octopart.com/90151-2116-Molex-datasheet-10854273.pdf?src-supplier=Newark; ComponentLink5Description: https://datasheet.octopart.com/90151-2116-Molex-datasheet-44403541.pdf?src-supplier=Electro+Sonic; ComponentLink5URL: https://datasheet.octopart.com/90151-2116-Molex-datasheet-44403541.pdf?src-supplier=Electro+Sonic; ComponentLink6Description: https://datasheet.octopart.com/90151-2116-Molex-datasheet-81455539.pdf?src-supplier=TME; ComponentLink6URL: https://datasheet.octopart.com/90151-2116-Molex-datasheet-81455539.pdf?src-supplier=TME; ComponentLink7Description: https://datasheet.octopart.com/90151-2116-Molex-datasheet-154761127.pdf?src-supplier=Master+Electronics; ComponentLink7URL: https://datasheet.octopart.com/90151-2116-Molex-datasheet-154761127.pdf?src-supplier=Master+Electronics; Manufacturer Part Number: 0901512116; Pricing 1: 1=3.89, 10=2.75, 25=2.67, 50=2.59, 100=2.52, 250=2.43 (USD); RoHS: Yes; Stock 1: 3895; Supplier 1: Newark; Supplier Part Number 1: 94M3066; Library Name: bBoard for UNB Rev 4.SCHLIB
Q2 Q3 = DTC143EET1G: Small Signal Bipolar Transistor, 0.1A I(C), 50V V(BR)CEO, 1-Element, NPN, Silicon; Manufacturer: ON Semiconductor; DC Current Gain-Min (hFE): 15; Max Collector Current: 100mA; Number of Elements: 1; Package Body Material: Plastic; Power Dissipation-Max (Abs): 300mW; Qualification Status: Not Qualified; Time @ Peak Reflow Temperature-Max (s): 40s; Transistor Application: SWITCHING; Category: BJTs; Collector-emitter Voltage-Max: 50V; Component Kind: Standard; ComponentLink1Description: https://datasheet.ciiva.com:443/pdfs/VipMasterIC/IC/ONSM/ONSM-S-A0002809175/ONSM-S-A0002809175-1.pdf?src-supplier=IHS+Markit; ComponentLink1URL: https://datasheet.ciiva.com:443/pdfs/VipMasterIC/IC/ONSM/ONSM-S-A0002809175/ONSM-S-A0002809175-1.pdf?src-supplier=IHS+Markit; ComponentLink2Description: https://datasheet.ciiva.com/15139/getdatasheetpartid-38523-15139560.pdf?src-supplier=Verical; ComponentLink2URL: https://datasheet.ciiva.com/15139/getdatasheetpartid-38523-15139560.pdf?src-supplier=Verical; ComponentLink3Description: https://datasheet.ciiva.com/990/dtc143e-d-990648.pdf?src-supplier=Digikey; ComponentLink3URL: https://datasheet.ciiva.com/990/dtc143e-d-990648.pdf?src-supplier=Digikey; ComponentLink4Description: https://datasheet.ciiva.com/8120/dtc124e-d-8120717.pdf?src-supplier=Avnet; ComponentLink4URL: https://datasheet.ciiva.com/8120/dtc124e-d-8120717.pdf?src-supplier=Avnet; JESD-30 Code: R-PDSO-G3; JESD-609 Code: e3; Manufacturer Part Number: DTC143EET1G; Max Operating Temperature: 150°C; Moisture Sensitivity Level: 1; Number of Pins: 3; Package Shape: Rectangular; Package Style: SMALL OUTLINEMeter; Peak Reflow Temperature (Cel): 260°C; Polarity/Channel Type: NPN; Pricing 1: 1=0.2, 10=0.14, 100=0.0684, 500=0.05708, 1000=0.03966 (USD); Reach SVHC Compliant: Yes; RoHS: Yes; RoHS Compliant: Yes; Stock 1: 287169; Supplier 1: Digikey; Supplier Part Number 1: DTC143EET1GOSDKR-ND; Surface Mount: Yes; Terminal Finish: Tin; Terminal Form: Gull Wing; Terminal Position: DUAL; Transistor Element Material: SILICON; Library Name: bBoard for UNB Rev 4.SCHLIB
Q4 = BSS816NW: N-Channel OptiMOS 2 Small-Signal-Transistor, 20 V VDS, 1.4 A ID, -55 to 150 degC, PG-SOT-323, Reel, Green; Manufacturer: Infineon; Green: TRUE; ID (max): 1.4Â A; IDpuls (max): 5.6Â A; Ptot (max): 0.5Â W; QG: 0.6Â nC; RDS (on) (max): 160 mOhm; RDS (on) (max) (@1.8V): 240 mOhm; RthJA (max): 250 K/W; VDS (max): 20 V; VGS(th) (max): 0.75Â V; VGS(th) (min): 0.3Â V; Component Kind: Standard; ComponentLink1Description: Manufacturer URL; ComponentLink1URL: http://www.infineon.com/; ComponentLink2Description: Datasheet; ComponentLink2URL: http://www.infineon.com/dgdl/BSS816NW_Rev2.3_.pdf?folderId=db3a3043156fd573011622e10b5c1f67&fileId=db3a304335113a6301351e704a2e1332; ComponentLink3Description: Package Specification; ComponentLink3URL: http://www.infineon.com/dgdl/SOT323-Package_Overview.pdf?folderId=db3a30431936bc4b0119533e1dcb3c92&fileId=db3a30431936bc4b011953495f283cb1; DatasheetVersion: Rev. 2.3; Halogen-free: TRUE; Mounting Technology: Surface Mount; PackageDescription: 3-Pin Small Outline Transistor Package, 2 x 1.25 mm Body, 0.65 mm Pitch; PackageReference: PG-SOT-323; PackageVersion: Apr-08; Packing: Reel; PartNumber: BSS816NW; RDS (on) (max) (@2.5V): 160 mOhm; Library Name: bBoard for UNB Rev 3.SCHLIB
R18-R20 R60 = RES MCWR04X1005FTL: Res General Purpose Thick Film 0402 10M Ohm 1% 1/16W ±100ppm/°C Ceramic Paper T/R; Manufacturer: Multicomp; Component Kind: Standard; ComponentLink1Description: https://datasheet.ciiva.com/46398/1849611-46398249.pdf?src-supplier=Element14; ComponentLink1URL: https://datasheet.ciiva.com/46398/1849611-46398249.pdf?src-supplier=Element14; Manufacturer Part Number: MCWR04X1005FTL; Pricing 1: 10=0.034, 100=0.028, 1000=0.02 (CAD); RoHS: Yes; Stock 1: 27572; Supplier 1: Newark; Supplier Part Number 1: 94X1108; Library Name: bBoard for UNB Rev 4.SCHLIB
R21 R22 R29 = RES MC00625W040211K: Resistor, Thick Film, 1K, 1%, 0.0625W, 0402; Manufacturer: Multicomp; Component Kind: Standard; Part Number: MC00625W040211K; Library Name: bBoard for UNB Rev 3.SCHLIB
R23 R28 = RES MC00625W0402110K: Fixed Resistor, Metal Glaze/thick Film, 0.0625W, 10000ohm, 50V, 1% +/-Tol, 100ppm/Cel, Surface Mount, 0402; Manufacturer: Multicomp; Rated Power Dissipation (P): 63mW; Rated Temperature: 70°C; Temperature Coefficient: 100ppm/°C; Category: Chip SMD Resistors; Component Kind: Standard; ComponentLink1Description: https://datasheet.ciiva.com:443/pdfs/VipMasterIC/IC/SCMP/SCMPS00098/SCMPS00098-1.pdf?src-supplier=IHS+Markit; ComponentLink1URL: https://datasheet.ciiva.com:443/pdfs/VipMasterIC/IC/SCMP/SCMPS00098/SCMPS00098-1.pdf?src-supplier=IHS+Markit; ComponentLink2Description: https://datasheet.ciiva.com/28638/1729045-28638923.pdf?src-supplier=Element14; ComponentLink2URL: https://datasheet.ciiva.com/28638/1729045-28638923.pdf?src-supplier=Element14; Manufacturer Part Number: MC00625W0402110K; Max Operating Temperature: 155°C; Min Operating Temperature: -55°C; Mount: Surface Mount; Number of Pins: 2; Package Shape: Rectangular; Packaging: Tape and Reel; Pricing 1: 10000=0.006, 20000=0.005, 50000=0.004, 100000=0.002 (USD); Reach SVHC Compliant: Yes; Resistor Type: FIXED RESISTOR; RoHS: Yes; RoHS Compliant: Yes; Size Code: 0402; Stock 1: 700000; Supplier 1: Newark; Supplier Part Number 1: 07W4403; Technology: METAL GLAZE/THICK FILM; Termination: Wraparound; Tolerance: 1%; Working Voltage: 50V; Library Name: bBoard for UNB Rev 3.SCHLIB
R24 = RES ERJ-2RKF1050X: Fixed Resistor, Metal Glaze/thick Film, 0.1W, 105ohm, 50V, 1% +/-Tol, 100ppm/Cel, Surface Mount, 0402; Manufacturer: Panasonic; Package Height: 0.35mm; Package Length: 1mm; Package Width: 0.5mm; Rated Power Dissipation (P): 100mW; Rated Temperature: 70°C; Reference Standard: AEC-Q200; Temperature Coefficient: 100ppm/°C; Category: Chip SMD Resistors; Component Kind: Standard; ComponentLink1Description: https://datasheet.ciiva.com:443/pdfs/VipMasterIC/IC/PANA/PANA-S-A0009889626/PANA-S-A0009889626-1.pdf?src-supplier=IHS+Markit; ComponentLink1URL: https://datasheet.ciiva.com:443/pdfs/VipMasterIC/IC/PANA/PANA-S-A0009889626/PANA-S-A0009889626-1.pdf?src-supplier=IHS+Markit; ComponentLink2Description: https://datasheet.ciiva.com/9119/aoa0000ce2-9119247.pdf?src-supplier=Avnet; ComponentLink2URL: https://datasheet.ciiva.com/9119/aoa0000ce2-9119247.pdf?src-supplier=Avnet; ComponentLink3Description: https://datasheet.ciiva.com/43467/1678782-43467224.pdf?src-supplier=Farnell; ComponentLink3URL: https://datasheet.ciiva.com/43467/1678782-43467224.pdf?src-supplier=Farnell; ComponentLink4Description: https://datasheet.ciiva.com/16764/70260748-16764263.pdf?src-supplier=Allied+Electronics; ComponentLink4URL: https://datasheet.ciiva.com/16764/70260748-16764263.pdf?src-supplier=Allied+Electronics; ComponentLink5Description: https://datasheet.ciiva.com/16768/70260748-16768203.pdf?src-supplier=Allied+Electronics; ComponentLink5URL: https://datasheet.ciiva.com/16768/70260748-16768203.pdf?src-supplier=Allied+Electronics; ComponentLink6Description: https://datasheet.ciiva.com/7903/1678782-7903401.pdf?src-supplier=Element14; ComponentLink6URL: https://datasheet.ciiva.com/7903/1678782-7903401.pdf?src-supplier=Element14; ComponentLink7Description: https://datasheet.ciiva.com/24069/aoa0000ce2-24069577.pdf?src-supplier=Mouser; ComponentLink7URL: https://datasheet.ciiva.com/24069/aoa0000ce2-24069577.pdf?src-supplier=Mouser; Construction: Rectangular; JESD-609 Code: e3; Manufacturer Part Number: ERJ-2RKF1050X; Max Operating Temperature: 155°C; Min Operating Temperature: -55°C; Mount: Surface Mount; Number of Pins: 2; Package Shape: Rectangular; Package Style: SMTMeter; Packaging: Tape and Reel; Pricing 1: 1=0.1, 10=0.024, 50=0.0152, 100=0.0127, 500=0.00866, 1000=0.00746, 5000=0.00542 (USD); Reach SVHC Compliant: Yes; Resistor Type: FIXED RESISTOR; RoHS: Yes; RoHS Compliant: Yes; Size Code: 0402; Stock 1: 5968; Supplier 1: Digikey; Supplier Part Number 1: P105LCT-ND; Technology: METAL GLAZE/THICK FILM; Terminal Finish: Nickel; Termination: Wraparound; Tolerance: 1%; Working Voltage: 50V; Library Name: bBoard for UNB Rev 4.SCHLIB
R25 = RES RC0402JR-0733KL: Fixed Resistor, Metal Glaze/thick Film, 0.0625W, 33000ohm, 50V, 5% +/-Tol, 100ppm/Cel, Surface Mount, 0402; Manufacturer: Yageo; Additional Feature: LASER TRIMMABLE; Package Height: 0.35mm; Package Length: 1mm; Package Width: 0.5mm; Rated Power Dissipation (P): 62.5mW; Rated Temperature: 70°C; Temperature Coefficient: 100ppm/°C; Category: Chip SMD Resistors; Component Kind: Standard; ComponentLink1Description: https://datasheet.ciiva.com:443/pdfs/VipMasterIC/IC/YAGO/YAGO-S-A0008145938/YAGO-S-A0008145938-1.pdf?src-supplier=IHS+Markit; ComponentLink1URL: https://datasheet.ciiva.com:443/pdfs/VipMasterIC/IC/YAGO/YAGO-S-A0008145938/YAGO-S-A0008145938-1.pdf?src-supplier=IHS+Markit; ComponentLink2Description: https://datasheet.ciiva.com/7850/1018594-7850198.pdf?src-supplier=Element14; ComponentLink2URL: https://datasheet.ciiva.com/7850/1018594-7850198.pdf?src-supplier=Element14; ComponentLink3Description: https://datasheet.ciiva.com/7850/1018594-7850199.pdf?src-supplier=Element14; ComponentLink3URL: https://datasheet.ciiva.com/7850/1018594-7850199.pdf?src-supplier=Element14; ComponentLink4Description: https://datasheet.ciiva.com/7983/1018594-7983578.pdf?src-supplier=Element14; ComponentLink4URL: https://datasheet.ciiva.com/7983/1018594-7983578.pdf?src-supplier=Element14; ComponentLink5Description: https://datasheet.ciiva.com/5558/pyu-rc0402-51-rohs-l-6-r-5558154.pdf?src-supplier=Mouser; ComponentLink5URL: https://datasheet.ciiva.com/5558/pyu-rc0402-51-rohs-l-6-r-5558154.pdf?src-supplier=Mouser; ComponentLink6Description: https://datasheet.ciiva.com/16740/pyu-rc0402-51-rohs-l-6-r-16740743.pdf?src-supplier=Future+Electronics; ComponentLink6URL: https://datasheet.ciiva.com/16740/pyu-rc0402-51-rohs-l-6-r-16740743.pdf?src-supplier=Future+Electronics; Construction: Chip; JESD-609 Code: e3; Manufacturer Part Number: RC0402JR-0733KL; Max Operating Temperature: 155°C; Min Operating Temperature: -55°C; Mount: Surface Mount; Number of Pins: 2; Package Shape: Rectangular; Package Style: SMTMeter; Packaging: Tape and Reel; Pricing 1: 1=0.1, 10=0.018, 50=0.0102, 100=0.0083, 500=0.00528, 1000=0.00444, 5000=0.00307 (USD); Reach SVHC Compliant: Yes; Resistor Type: FIXED RESISTOR; RoHS: Yes; RoHS Compliant: Yes; Size Code: 0402; Stock 1: 166093; Supplier 1: Digikey; Supplier Part Number 1: 311-33KJRCT-ND; Technology: METAL GLAZE/THICK FILM; Terminal Finish: Nickel; Termination: Wraparound; Tolerance: 5%; Working Voltage: 50V; Library Name: bBoard for UNB Rev 4.SCHLIB
R26 = RES RC0402JR-0768RL: Fixed Resistor, Metal Glaze/thick Film, 0.0625W, 68ohm, 50V, 5% +/-Tol, 100ppm/Cel, Surface Mount, 0402; Manufacturer: Yageo; Additional Feature: LASER TRIMMABLE; Package Height: 0.35mm; Package Length: 1mm; Package Width: 0.5mm; Rated Power Dissipation (P): 62.5mW; Rated Temperature: 70°C; Temperature Coefficient: 100ppm/°C; Category: Chip SMD Resistors; Component Kind: Standard; ComponentLink1Description: https://datasheet.ciiva.com:443/pdfs/VipMasterIC/IC/YAGO/YAGO-S-A0008145938/YAGO-S-A0008145938-1.pdf?src-supplier=IHS+Markit; ComponentLink1URL: https://datasheet.ciiva.com:443/pdfs/VipMasterIC/IC/YAGO/YAGO-S-A0008145938/YAGO-S-A0008145938-1.pdf?src-supplier=IHS+Markit; ComponentLink2Description: https://datasheet.ciiva.com/7983/1018594-7983610.pdf?src-supplier=Element14; ComponentLink2URL: https://datasheet.ciiva.com/7983/1018594-7983610.pdf?src-supplier=Element14; ComponentLink3Description: https://datasheet.ciiva.com/2518/pyu-r-int-thick-7-2518895.pdf?src-supplier=Digikey; ComponentLink3URL: https://datasheet.ciiva.com/2518/pyu-r-int-thick-7-2518895.pdf?src-supplier=Digikey; ComponentLink4Description: https://datasheet.ciiva.com/2518/pyu-r-int-thick-7-2518896.pdf?src-supplier=Digikey; ComponentLink4URL: https://datasheet.ciiva.com/2518/pyu-r-int-thick-7-2518896.pdf?src-supplier=Digikey; ComponentLink5Description: https://datasheet.ciiva.com/2518/pyu-r-int-thick-7-2518897.pdf?src-supplier=Digikey; ComponentLink5URL: https://datasheet.ciiva.com/2518/pyu-r-int-thick-7-2518897.pdf?src-supplier=Digikey; ComponentLink6Description: https://datasheet.ciiva.com/17055/pyu-rc0402-51-rohs-l-6-r-17055789.pdf?src-supplier=Future+Electronics; ComponentLink6URL: https://datasheet.ciiva.com/17055/pyu-rc0402-51-rohs-l-6-r-17055789.pdf?src-supplier=Future+Electronics; Construction: Chip; JESD-609 Code: e3; Manufacturer Part Number: RC0402JR-0768RL; Max Operating Temperature: 155°C; Min Operating Temperature: -55°C; Mount: Surface Mount; Number of Pins: 2; Package Shape: Rectangular; Package Style: SMTMeter; Packaging: Tape and Reel; Pricing 1: 1=0.1, 10=0.018, 50=0.0102, 100=0.0083, 500=0.00528, 1000=0.00444, 5000=0.00307 (USD); Reach SVHC Compliant: Yes; Resistor Type: FIXED RESISTOR; RoHS: Yes; RoHS Compliant: Yes; Size Code: 0402; Stock 1: 144890; Supplier 1: Digikey; Supplier Part Number 1: 311-68JRCT-ND; Technology: METAL GLAZE/THICK FILM; Terminal Finish: Nickel; Termination: Wraparound; Tolerance: 5%; Working Voltage: 50V; Library Name: bBoard for UNB Rev 4.SCHLIB
R27 R30 R43 = RES RC0402FR-074K7L: Fixed Resistor, Metal Glaze/thick Film, 0.0625W, 4700ohm, 50V, 1% +/-Tol, 100ppm/Cel, Surface Mount, 0402; Manufacturer: Yageo; Additional Feature: LASER TRIMMABLE; Package Height: 0.35mm; Package Length: 1mm; Package Width: 0.5mm; Rated Power Dissipation (P): 62.5mW; Rated Temperature: 70°C; Temperature Coefficient: 100ppm/°C; Category: Chip SMD Resistors; Component Kind: Standard; ComponentLink1Description: https://datasheet.ciiva.com:443/pdfs/VipMasterIC/IC/YAGO/YAGO-S-A0008145938/YAGO-S-A0008145938-1.pdf?src-supplier=IHS+Markit; ComponentLink1URL: https://datasheet.ciiva.com:443/pdfs/VipMasterIC/IC/YAGO/YAGO-S-A0008145938/YAGO-S-A0008145938-1.pdf?src-supplier=IHS+Markit; ComponentLink2Description: https://datasheet.ciiva.com/7850/1018594-7850022.pdf?src-supplier=Element14; ComponentLink2URL: https://datasheet.ciiva.com/7850/1018594-7850022.pdf?src-supplier=Element14; ComponentLink3Description: https://datasheet.ciiva.com/7850/1018594-7850023.pdf?src-supplier=Element14; ComponentLink3URL: https://datasheet.ciiva.com/7850/1018594-7850023.pdf?src-supplier=Element14; Construction: Chip; JESD-609 Code: e3; Manufacturer Part Number: RC0402FR-074K7L; Max Operating Temperature: 155°C; Min Operating Temperature: -55°C; Mount: Surface Mount; Number of Pins: 2; Package Shape: Rectangular; Package Style: SMTMeter; Packaging: Tape and Reel; Pricing 1: 1=0.1, 10=0.015, 50=0.0088, 100=0.0073, 500=0.00488, 1000=0.00417, 5000=0.00297 (USD); Reach SVHC Compliant: Yes; Resistor Type: FIXED RESISTOR; RoHS: Yes; RoHS Compliant: Yes; Size Code: 0402; Stock 1: 6932575; Supplier 1: Digikey; Supplier Part Number 1: 311-4.7KLRCT-ND; Technology: METAL GLAZE/THICK FILM; Terminal Finish: Nickel; Termination: Wraparound; Tolerance: 1%; Working Voltage: 50V; Library Name: bBoard for UNB Rev 4.SCHLIB
R42 = RES RC0603JR-07120RL: Fixed Resistor, Metal Glaze/thick Film, 0.1W, 120ohm, 75V, 5% +/-Tol, 100ppm/Cel, Surface Mount, 0603; Manufacturer: Yageo; Additional Feature: LASER TRIMMABLE; Package Height: 0.45mm; Package Length: 1.6mm; Package Width: 0.8mm; Rated Power Dissipation (P): 100mW; Rated Temperature: 70°C; Resistance: 120R; Temperature Coefficient: 100ppm/°C; Category: Chip SMD Resistors; Component Kind: Standard; ComponentLink1Description: https://datasheet.ciiva.com:443/pdfs/VipMasterIC/IC/YAGO/YAGO-S-A0008145938/YAGO-S-A0008145938-1.pdf?src-supplier=IHS+Markit; ComponentLink1URL: https://datasheet.ciiva.com:443/pdfs/VipMasterIC/IC/YAGO/YAGO-S-A0008145938/YAGO-S-A0008145938-1.pdf?src-supplier=IHS+Markit; ComponentLink2Description: https://datasheet.ciiva.com/15189/getdatasheetpartid-326532-15189850.pdf?src-supplier=Verical; ComponentLink2URL: https://datasheet.ciiva.com/15189/getdatasheetpartid-326532-15189850.pdf?src-supplier=Verical; ComponentLink3Description: https://datasheet.ciiva.com/12555/rc0603-272842-12555246.pdf?src-supplier=Mouser; ComponentLink3URL: https://datasheet.ciiva.com/12555/rc0603-272842-12555246.pdf?src-supplier=Mouser; ComponentLink4Description: https://datasheet.ciiva.com/2518/pyu-r-int-thick-7-2518850.pdf?src-supplier=Digikey; ComponentLink4URL: https://datasheet.ciiva.com/2518/pyu-r-int-thick-7-2518850.pdf?src-supplier=Digikey; ComponentLink5Description: https://datasheet.ciiva.com/2518/pyu-r-int-thick-7-2518851.pdf?src-supplier=Digikey; ComponentLink5URL: https://datasheet.ciiva.com/2518/pyu-r-int-thick-7-2518851.pdf?src-supplier=Digikey; ComponentLink6Description: https://datasheet.ciiva.com/2518/pyu-r-int-thick-7-2518852.pdf?src-supplier=Digikey; ComponentLink6URL: https://datasheet.ciiva.com/2518/pyu-r-int-thick-7-2518852.pdf?src-supplier=Digikey; ComponentLink7Description: https://datasheet.ciiva.com/46395/443971-46395070.pdf?src-supplier=Element14; ComponentLink7URL: https://datasheet.ciiva.com/46395/443971-46395070.pdf?src-supplier=Element14; Construction: Chip; JESD-609 Code: e3; Manufacturer Part Number: RC0603JR-07120RL; Max Operating Temperature: 155°C; Min Operating Temperature: -55°C; Mount: Surface Mount; Number of Pins: 2; Package Shape: Rectangular; Package Style: SMTMeter; Packaging: Tape and Reel; Pricing 1: 1=0.1, 10=0.015, 50=0.0092, 100=0.0076, 500=0.00512, 1000=0.00437 (USD); Reach SVHC Compliant: Yes; Resistor Type: FIXED RESISTOR; RoHS: Yes; RoHS Compliant: Yes; Size Code: 0603; Stock 1: 211497; Supplier 1: Digikey; Supplier Part Number 1: 311-120GRCT-ND; Technology: METAL GLAZE/THICK FILM; Terminal Finish: Nickel; Termination: Wraparound; Tolerance: 5%; Working Voltage: 75V; Library Name: bBoard for UNB Rev 4.SCHLIB
R44 = RES MCWR04X1003FTL: Fixed Resistor, Metal Glaze/thick Film, 0.0625W, 100000ohm, 50V, 1% +/-Tol, 100ppm/Cel, Surface Mount, 0402; Manufacturer: Multicomp; Rated Power Dissipation (P): 62.5mW; Rated Temperature: 70°C; Temperature Coefficient: 100ppm/°C; Component Kind: Standard; ComponentLink1Description: https://datasheet.ciiva.com:443/pdfs/VipMasterIC/IC/SCMP/SCMP-S-A0002061452/SCMP-S-A0002061452-1.pdf?src-supplier=IHS+Markit; ComponentLink1URL: https://datasheet.ciiva.com:443/pdfs/VipMasterIC/IC/SCMP/SCMP-S-A0002061452/SCMP-S-A0002061452-1.pdf?src-supplier=IHS+Markit; ComponentLink2Description: https://datasheet.ciiva.com/46386/1849611-46386524.pdf?src-supplier=Element14; ComponentLink2URL: https://datasheet.ciiva.com/46386/1849611-46386524.pdf?src-supplier=Element14; JESD-609 Code: e3; Manufacturer Part Number: MCWR04X1003FTL; Max Operating Temperature: 155°C; Min Operating Temperature: -55°C; Mount: Surface Mount; Number of Pins: 2; Package Shape: Rectangular; Packaging: Tape and Reel; Pricing 1: 1=0.028, 10=0.024, 100=0.02, 1000=0.014 (USD); Resistor Type: FIXED RESISTOR; RoHS: Yes; RoHS Compliant: Yes; Size Code: 0402; Stock 1: 29891; Supplier 1: Newark; Supplier Part Number 1: 94X1105; Technology: METAL GLAZE/THICK FILM; Terminal Finish: Tin; Termination: Wraparound; Tolerance: 1%; Working Voltage: 50V; Library Name: bBoard for UNB Rev 3.SCHLIB
R45 R59 = RES MCSR04X000PTL: Res Jumper Thick Film 0402 0 Ohm 1/16W Ceramic Paper T/R; Manufacturer: Multicomp; Category: Chip SMD Resistors; Component Kind: Standard; ComponentLink1Description: https://datasheet.ciiva.com/7777/1716710-7777064.pdf?src-supplier=Element14; ComponentLink1URL: https://datasheet.ciiva.com/7777/1716710-7777064.pdf?src-supplier=Element14; Manufacturer Part Number: MCSR04X000PTL; Pricing 1: 10=0.025, 25=0.018, 50=0.013, 100=0.01, 500=0.008, 1000=0.006, 5000=0.004 (USD); RoHS: Yes; Stock 1: 65769; Supplier 1: Newark; Supplier Part Number 1: 86T4050
  R45: Library Name: bBoard for UNB Rev 3.SCHLIB
  R59: Library Name: bBoard for UNB Rev 4.SCHLIB
